# Altium schematic sheet 03_DATA_CON.SchDoc(03_DATA_CON)
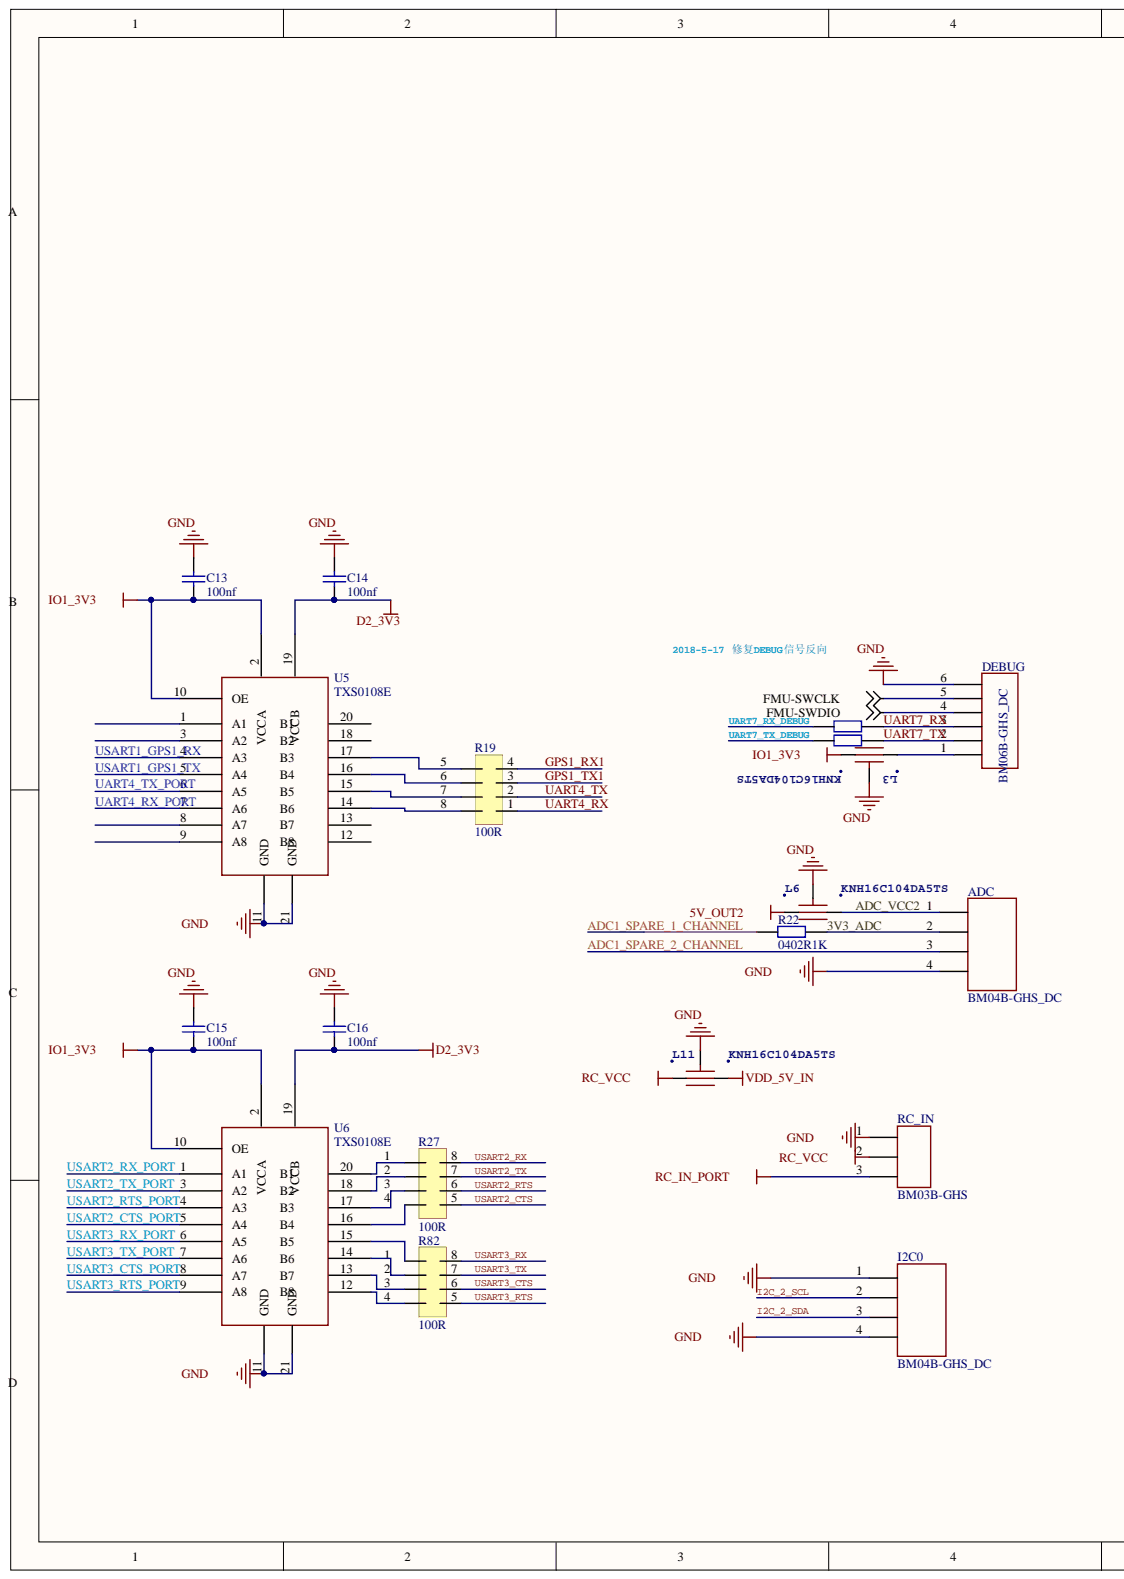
[diagram p0: 03_DATA_CON.SchDoc, left]
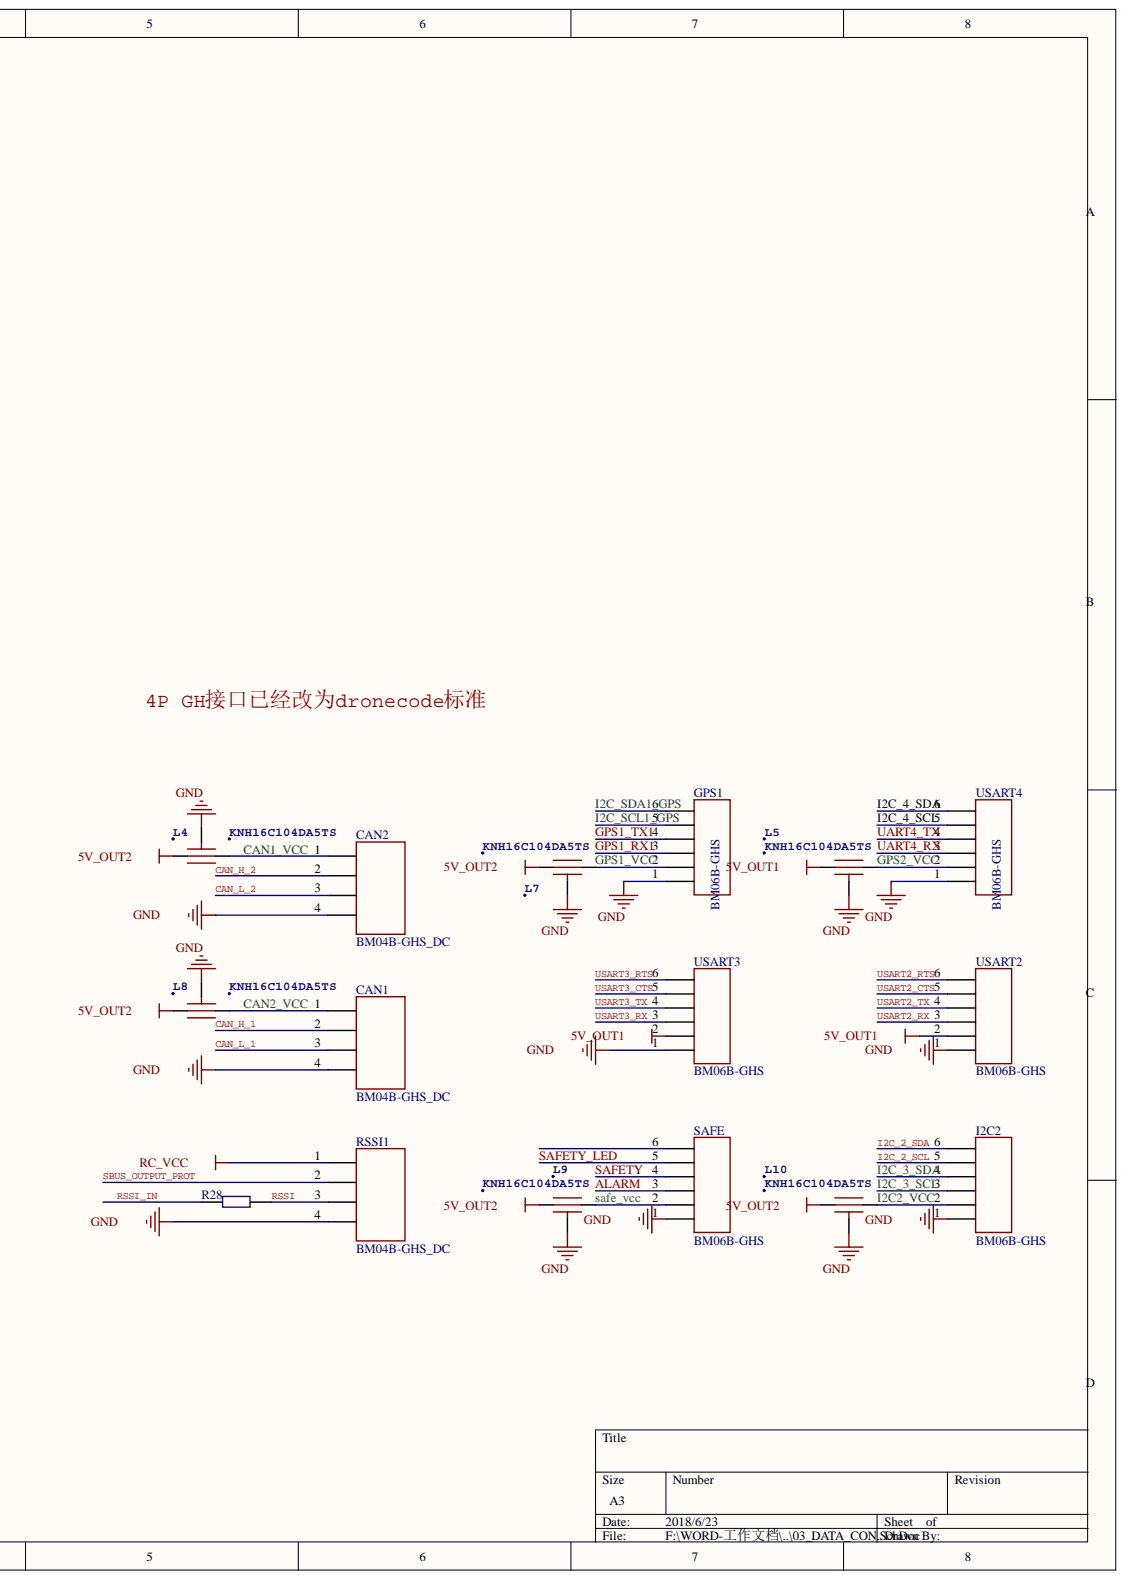
[diagram p1: 03_DATA_CON.SchDoc, right]

## Parts
ADC  BM04B-GHS_DC  [BM04B-GHS_DC]
C13  100nf  [0402CAP]
C14  100nf  [0402CAP]
C15  100nf  [0402CAP]
C16  100nf  [0402CAP]
CAN1  BM04B-GHS_DC  [BM04B-GHS_DC]
CAN2  BM04B-GHS_DC  [BM04B-GHS_DC]
DEBUG  BM06B-GHS_DC  [BM06B-GHS_DC]
GPS1  BM06B-GHS  [BM06B-GHS]
I2C0  BM04B-GHS_DC  [BM04B-GHS_DC]
I2C2  BM06B-GHS  [BM06B-GHS]
L3  KNH16C104DA5TS  [KYOCERA-KNH16-DA]
L4  KNH16C104DA5TS  [KYOCERA-KNH16-DA]
L5  KNH16C104DA5TS  [KYOCERA-KNH16-DA]
L6  KNH16C104DA5TS  [KYOCERA-KNH16-DA]
L7  KNH16C104DA5TS  [KYOCERA-KNH16-DA]
L8  KNH16C104DA5TS  [KYOCERA-KNH16-DA]
L9  KNH16C104DA5TS  [KYOCERA-KNH16-DA]
L10  KNH16C104DA5TS  [KYOCERA-KNH16-DA]
L11  KNH16C104DA5TS  [KYOCERA-KNH16-DA]
R19  100R  [0402_4r]
R22  0402R1K  [0402R]
R25  0402R100R  [0402R]  (value not drawn)
R27  100R  [0402_4r]
R28  0402R100R  [0402R]  (value not drawn)
R47  0402R100R  [0402R]  (value not drawn)
R82  100R  [0402_4r]
RC_IN  BM03B-GHS  [BM03B-GHS_CUAV]
RSSI1  BM04B-GHS_DC  [BM04B-GHS_DC]
SAFE  BM06B-GHS  [BM06B-GHS]
U5  TXS0108E  [VQFN20]
U6  TXS0108E  [VQFN20]
USART2  BM06B-GHS  [BM06B-GHS]
USART3  BM06B-GHS  [BM06B-GHS]
USART4  BM06B-GHS  [BM06B-GHS]

## Nets
5V_OUT1: L5.P$1 USART2.2 USART3.2
5V_OUT2: L4.P$2 L6.P$2 L7.P$1 L8.P$2 L9.P$1 L10.P$1
D2_3V3: C14.2 C16.2 U5.19 U6.19
FMU-SWCLK: DEBUG.5
FMU-SWDIO: DEBUG.4
GND: ADC.4 C13.1 C14.1 C15.1 C16.1 CAN1.4 CAN2.4 DEBUG.6 GPS1.1 I2C0.1 I2C0.4 I2C2.1 L3.GND L4.GND L5.GND L6.GND L7.GND L8.GND L9.GND L10.GND L11.GND RC_IN.1 RSSI1.4 SAFE.1 U5.11(GND) U5.21(GND) U6.11(GND) U6.21(GND) USART2.1 USART3.1 USART4.1
IO1_3V3: C13.2 C15.2 L3.P$1 U5.2 U5.10(OE) U6.2 U6.10(OE)
RC_IN_PORT: RC_IN.3
RC_VCC: L11.P$2 RC_IN.2 RSSI1.1
VDD_5V_IN: L11.P$1
3V3_ADC: ADC.2 R22.2
ADC1_SPARE_1_CHANNEL: R22.1
ADC1_SPARE_2_CHANNEL: ADC.3
ADC_VCC2: ADC.1 L6.P$1
ALARM: SAFE.3
CAN1_VCC: CAN2.1 L4.P$1
CAN2_VCC: CAN1.1 L8.P$1
CAN_H_1: CAN1.2
CAN_H_2: CAN2.2
CAN_L_1: CAN1.3
CAN_L_2: CAN2.3
GPS1_RX1: GPS1.3 R19.4
GPS1_TX1: GPS1.4 R19.3
GPS1_VCC: GPS1.2 L7.P$2
GPS2_VCC: L5.P$2 USART4.2
I2C2_VCC: I2C2.2 L10.P$2
I2C_2_SCL: I2C0.2 I2C2.5
I2C_2_SDA: I2C0.3 I2C2.6
I2C_3_SCL: I2C2.3
I2C_3_SDA: I2C2.4
I2C_4_SCL: USART4.5
I2C_4_SDA: USART4.6
I2C_SCL1_GPS: GPS1.5
I2C_SDA1_GPS: GPS1.6
RSSI: R28.2 RSSI1.3
RSSI_IN: R28.1
SAFE_VCC: L9.P$2 SAFE.2
SAFETY: SAFE.4
SAFETY_LED: SAFE.5
SBUS_OUTPUT_PROT: RSSI1.2
UART4_RX: R19.1 USART4.3
UART4_RX_PORT: U5.7(A6)
UART4_TX: R19.2 USART4.4
UART4_TX_PORT: U5.6(A5)
UART7_RX: DEBUG.3 R25.2
UART7_RX_DEBUG: R25.1
UART7_TX: DEBUG.2 R47.2
UART7_TX_DEBUG: R47.1
USART1_GPS1_RX: U5.4(A3)
USART1_GPS1_TX: U5.5(A4)
USART2_CTS: R27.5 USART2.5
USART2_CTS_PORT: U6.5(A4)
USART2_RTS: R27.6 USART2.6
USART2_RTS_PORT: U6.4(A3)
USART2_RX: R27.8 USART2.3
USART2_RX_PORT: U6.1(A1)
USART2_TX: R27.7 USART2.4
USART2_TX_PORT: U6.3(A2)
USART3_CTS: R82.6 USART3.5
USART3_CTS_PORT: U6.8(A7)
USART3_RTS: R82.5 USART3.6
USART3_RTS_PORT: U6.9(A8)
USART3_RX: R82.8 USART3.3
USART3_RX_PORT: U6.6(A5)
USART3_TX: R82.7 USART3.4
USART3_TX_PORT: U6.7(A6)
NetDEBUG_1: DEBUG.1 L3.P$2
NetR19_5: R19.5 U5.17
NetR19_6: R19.6 U5.16
NetR19_7: R19.7 U5.15
NetR19_8: R19.8 U5.14
NetR27_1: R27.1 U6.20
NetR27_2: R27.2 U6.18
NetR27_3: R27.3 U6.17
NetR27_4: R27.4 U6.16
NetR82_1: R82.1 U6.15
NetR82_2: R82.2 U6.14
NetR82_3: R82.3 U6.13
NetR82_4: R82.4 U6.12
4P GH接口已经改为DRONECODE标准:
2018-5-17 修复DEBUG信号反向:

Not connected: SAFE 6; U5 1(A1) 3(A2) 8(A7) 9(A8) 12 13 18 20

## Library data of the parts
ADC = SM04B-GHS-TB: Component Kind: Standard; Library Name: CUAV.SchLib
C13-C16 = Cap: Component Kind: Standard; Library Name: Miscellaneous Devices.IntLib; Signal Integrity: Cap; Simulation: CAP
CAN1 CAN2 I2C0 RSSI1 = SM04B-GHS-TB: dronecode; Component Kind: Standard; Library Name: CUAV.SchLib
DEBUG = SM06B-GHS-TB: dronecode; Component Kind: Standard; Library Name: CUAV.SchLib
GPS1 I2C2 SAFE USART2-USART4 = SM06B-GHS-TB: Component Kind: Standard; Library Name: CUAV.SchLib
L3-L11 = KNH16C104DA5TS: Capacitor, Filter, 0.1uF, 25V, 2A, 0603, SMD; 3DR_PARTNO: ECM0719; Component Kind: Standard; Library Name: PCA_0005_FULL_CB_REV_A.SCHLIB; Supplier 1: Digi-Key
R19 R27 R82 = 0402-8R-4R: Component Kind: Standard; Library Name: CUAV.SchLib
R22 R25 R47 = 0402R1K: 0402R1K 1%; Component Kind: Standard; Library Name: CUAV.SchLib
R28 = 0402R100R: 0402R100R 1%; Component Kind: Standard; Library Name: CUAV.SchLib
RC_IN = SM03B-GHS: Component Kind: Standard; Library Name: CUAV.SchLib
U5 U6 = TXS0108E: Component Kind: Standard; Library Name: CUAV.SchLib
